# Altium schematic sheet A-Power.SchDoc(A-Power)
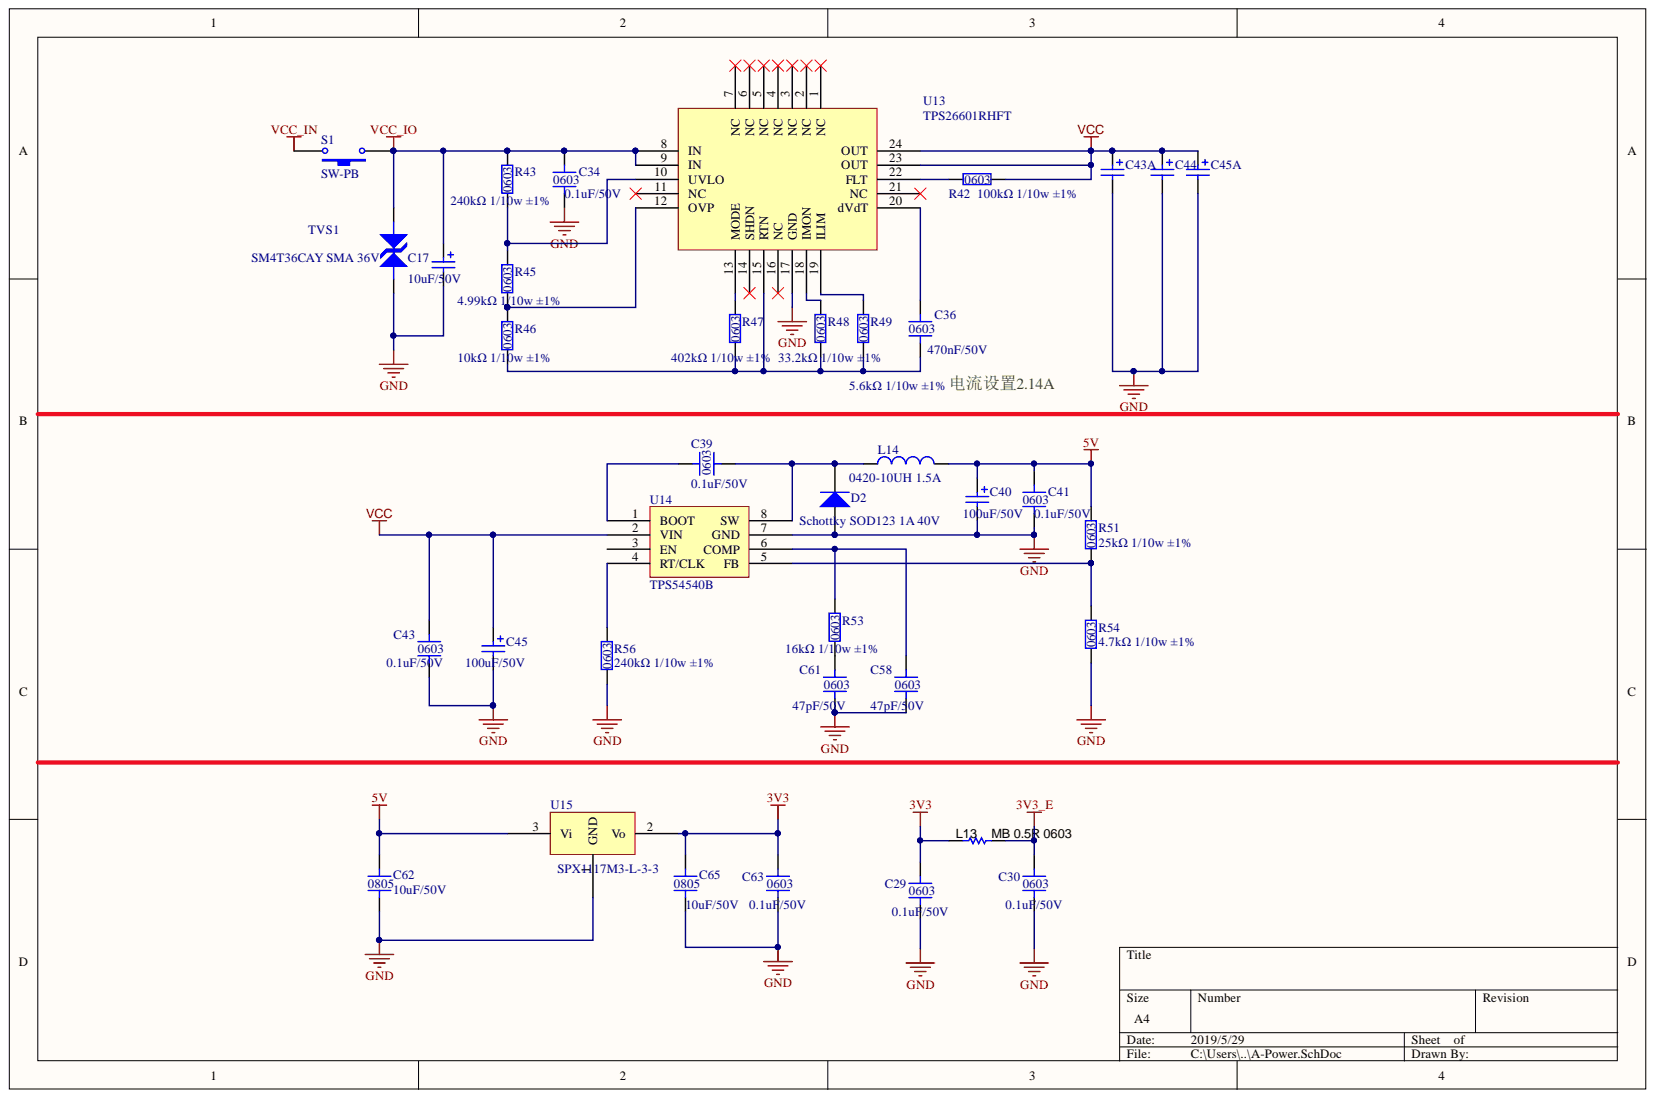
[diagram p0: A-Power.SchDoc]

## Parts
C17  10uF/50V  [C-1206]
C29  0.1uF/50V  [C-0603]
C30  0.1uF/50V  [C-0603]
C34  0.1uF/50V  [C-0603]
C36  470nF/50V  [C-0603]
C39  0.1uF/50V  [C-0603]
C40  100uF/50V  [SMD-4532]
C41  0.1uF/50V  [C-0603]
C43  0.1uF/50V  [C-0603]
C43A  CAP6.3*7.7 100UF 35V  [CAP-SMD-6.3*7.7]  (value not drawn)
C44  CAP6.3*7.7 100UF 35V  [CAP-SMD-6.3*7.7]  (value not drawn)
C45  100uF/50V  [SMD-4532]
C45A  CAP6.3*7.7 100UF 35V  [CAP-SMD-6.3*7.7]  (value not drawn)
C58  47pF/50V  [C-0603]
C61  47pF/50V  [C-0603]
C62  10uF/50V  [C-0805]
C63  0.1uF/50V  [C-0603]
C65  10uF/50V  [C-0805]
D2  Schottky SOD123 1A 40V  [SOD-123]
L13  MB 0.5R 0603  [R-0603]
L14  0420-10UH 1.5A  [INDUCTOR]
R42  100kΩ 1/10w ±1%  [R-0603]
R43  240kΩ 1/10w ±1%  [R-0603]
R45  4.99kΩ 1/10w ±1%  [R-0603]
R46  10kΩ 1/10w ±1%  [R-0603]
R47  402kΩ 1/10w ±1%  [R-0603]
R48  33.2kΩ 1/10w ±1%  [R-0603]
R49  5.6kΩ 1/10w ±1%  [R-0603]
R51  25kΩ 1/10w ±1%  [R-0603]
R53  16kΩ 1/10w ±1%  [R-0603]
R54  4.7kΩ 1/10w ±1%  [R-0603]
R56  240kΩ 1/10w ±1%  [R-0603]
S1  SW-PB  [SWITCH_DC]
TVS1  SM4T36CAY SMA 36V  [DO-214AA(CA)]
U13  TPS26601RHFT  [R-PVQFN-N24]
U14  TPS54540B  [TPS54540B]
U15  SPX1117M3-L-3-3  [SOT-223(M3)]

## Nets
3V3: C29.2 C63.2 C65.2 L13.1 U15.2
3V3_E: C30.2 L13.2
5V: C40.1 C41.2 C62.2 L14.2 R51.2 U15.3
GND: C17.2 C29.1 C30.1 C34.1 C40.2 C41.1 C43.1 C43A.2 C44.2 C45.2 C45A.2 C58.1 C61.1 C62.1 C63.1 C65.1 D2.1 R54.1 R56.1 TVS1.1 U13.17(GND) U14.7(GND) U15.1
VCC: C43.2 C43A.1 C44.1 C45.1 C45A.1 R42.2 U13.23(OUT) U13.24(OUT) U14.2(VIN)
VCC_IN: S1.2
VCC_IO: C17.1 C34.2 R43.2 S1.1 TVS1.2 U13.8(IN) U13.9(IN)
NetC36_1: C36.1 R46.1 R47.1 R48.1 R49.1 U13.15(RTN)
NetC36_2: C36.2 U13.20(dVdT)
NetC39_1: C39.1 D2.2 L14.1 U14.8(SW)
NetC39_2: C39.2 U14.1(BOOT)
NetC58_2: C58.2 R53.2 U14.6(COMP)
NetC61_2: C61.2 R53.1
NetR42_1: R42.1 U13.22(FLT)
NetR43_1: R43.1 R45.2 U13.10(UVLO)
NetR45_1: R45.1 R46.2 U13.12(OVP)
NetR47_2: R47.2 U13.13(MODE)
NetR48_2: R48.2 U13.18(IMON)
NetR49_2: R49.2 U13.19(ILIM)
NetR51_1: R51.1 R54.2 U14.5(FB)
NetR56_2: R56.2 U14.4(RT/CLK)

Not connected: U13 1(NC) 2(NC) 3(NC) 4(NC) 5(NC) 6(NC) 7(NC) 11(NC) 14(SHDN) 16(NC) 21(NC); U14 3(EN)

## Library data of the parts
C17 C40 C45 = Cap Pol2: Polarized Capacitor (Axial); Value: 100UF 50V; Component Kind: Standard; LatestRevisionDate: 17-Jul-2002; LatestRevisionNote: Re-released for DXP Platform.; Library Name: Miscellaneous Devices.IntLib; PackageDescription: Polarized Capacitor; 2 Leads; PackageReference: POLAR0.8; Published: 8-Jun-2000; Signal Integrity: Cap Pol; Simulation: CAP; Publisher: Altium Limited
C29 C30 C34 C36 C39 C41 C43 C58 C61 C63 = 10uF/50V: Component Kind: Standard; Library Name: dahuan.SchLib
C43A C44 C45A = Cap Pol2: Polarized Capacitor (Axial); Component Kind: Standard; LatestRevisionDate: 17-Jul-2002; LatestRevisionNote: Re-released for DXP Platform.; Library Name: Miscellaneous Devices.IntLib; PackageDescription: Polarized Capacitor; 2 Leads; PackageReference: POLAR0.8; Published: 8-Jun-2000; Signal Integrity: Cap Pol; Simulation: CAP; Value: 100UF 35V; Publisher: Altium Limited
C62 C65 = 100uF/50V: Component Kind: Standard; Library Name: dahuan.SchLib
D2 = Diode 1N4148: High Conductance Fast Diode; Code_JEDEC: DO-204-AH; Component Kind: Standard; ComponentLink1Description: Manufacturer Link; ComponentLink1URL: http://www.fairchildsemi.com/; ComponentLink2Description: Datasheet; ComponentLink2URL: http://www.fairchildsemi.com/ds/1N%2F1N4148.pdf; DatasheetVersion: 1997; LatestRevisionDate: 17-Jul-2002; LatestRevisionNote: Re-released for DXP Platform.; Library Name: Miscellaneous Devices.IntLib; PackageDescription: Diode, Axial; Body 3.8 x 1.9 mm (LxDia. max), Lead Dia. 0.53 mm (max); PackageReference: DO-35; Published: 8-Jun-2000; Signal Integrity: 1N4148; Simulation: 1N4148; Publisher: Altium Limited
L13 = RC0603FR-074K99L: magnetic bead; Manufacturer: Yageo America; CompType: Resistor; EIA_Code: E96; Power_W: 0.1; Res_ohm: 4990; TempCo: 200 ppm/C; Tolerance_%: 1; U/M: EA; Value: 4.99k; Value1: 1%; Value2: 0.1W; Value_Code: 4k99; VDC_V: 150; Component Kind: Standard; ComponentLink1Description: Datasheet link; ComponentLink1URL: http://www.yageo.com/pdf/Pu-RC0603_51_PbFree_L_2.pdf; LatestRevisionDate: 2014-12-16; Library Name: Resistor_0603.IntLib; MountType: SMT; PackageReference: 0603; PartNumber: RC0603FR-074K99L; Published: 2008-06-26; RoHS: Y; Signal Integrity: Resistor; Simulation: Resistor; Supplier 1: Digi-Key; Supplier 2: Mouser; Supplier 3: Farnell; Supplier Part Number 1: 311-4.99KHRCT-ND; Supplier Part Number 2: 603-RC0603FR-074K99L; Supplier Part Number 3: 1799345; SymbolVersion: 2009-05-29; Technology: Thick Film; Publisher: Texas Instruments
L14 = Inductor: Code_IEC: 1005; Code_JEITA: 0402; Component Kind: Standard; Description: Inductor; LatestRevisionDate: 29-May-2009; LatestRevisionNote: IPC-7351 Footprint Added.; Library Name: Miscellaneous Devices.IntLib; PackageDescription: Chip Inductor; PackageReference: 0402-A; Published: 8-Jun-2000; Signal Integrity: Inductor; Simulation: INDUCTOR; Value: 10uH 1.5A; Publisher: Altium Limited
R42 R43 R45-R49 R51 R53 R54 R56 = 2kΩ 1/10w ±1%: Component Kind: Standard; Library Name: dahuan.SchLib
S1 = SW-PB: Switch; Component Kind: Standard; LatestRevisionDate: 17-Jul-2002; LatestRevisionNote: Re-released for DXP Platform.; Library Name: Miscellaneous Devices.IntLib; PackageDescription: Switch; 2 Leads; PackageReference: SPST-2; Published: 24-Mar-1999; Publisher: Altium Limited
TVS1 = BioTVS: Component Kind: Standard; Library Name: DH-SchLib.SchLib
U13 = TPS26601: Component Kind: Standard; Library Name: DH-SchLib.SchLib
U15 = SOT-223(M3): Component Kind: Standard; Library Name: dahuan.SchLib
U14 = TPS54540B: Component Kind: Standard; Library Name: DH-SchLib.SchLib
